# Altium schematic sheet PowerSupply.SchDoc(PowerSupply)
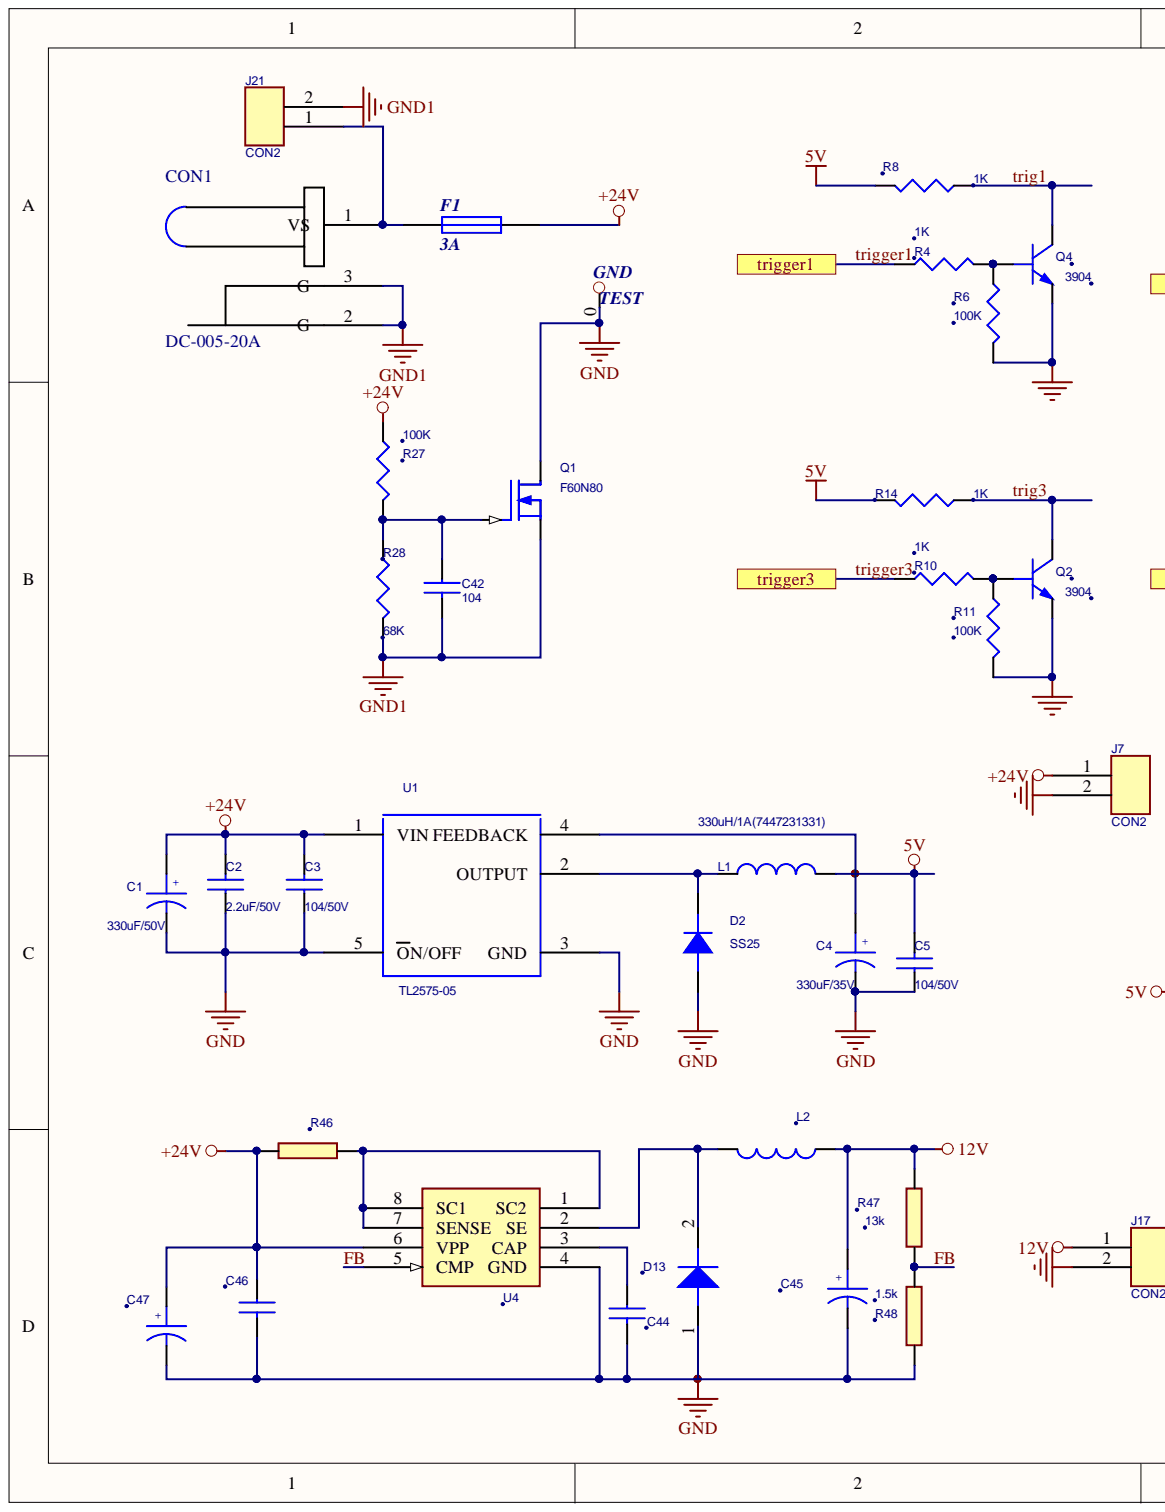
[diagram p0: PowerSupply.SchDoc, left]
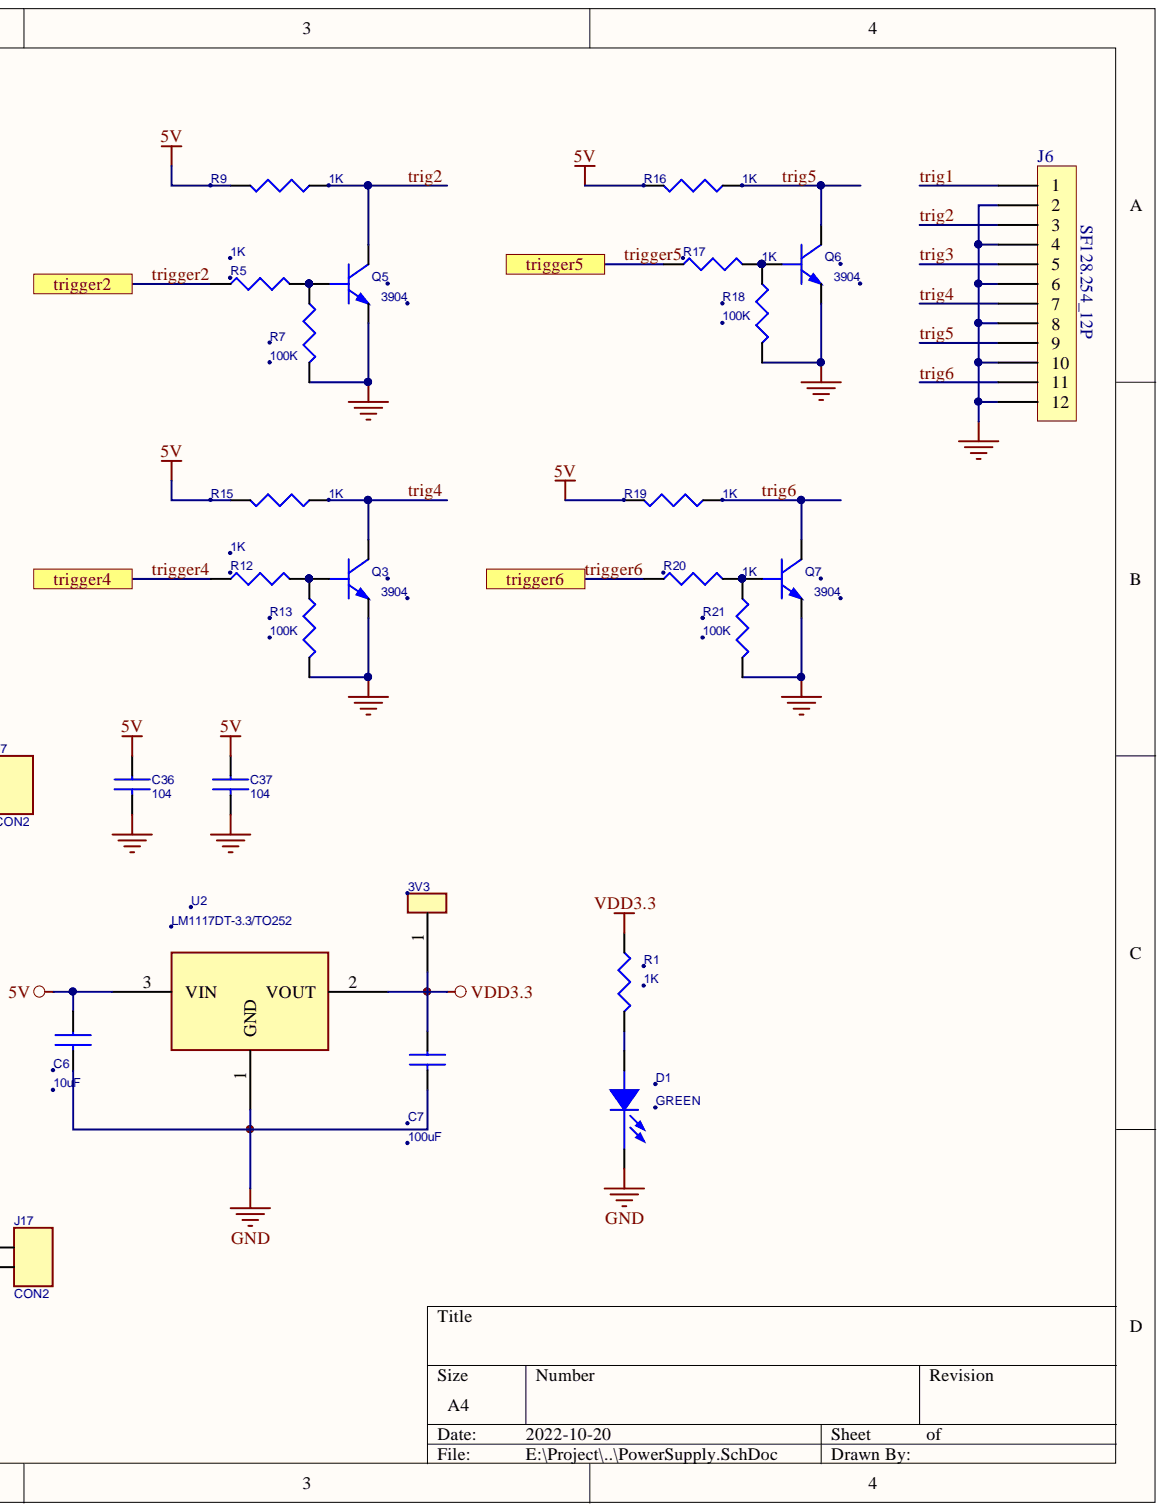
[diagram p1: PowerSupply.SchDoc, right]

## Parts
3V3  TEST POINT  [TEST2]  (value not drawn)
C1  330uF/50V  [EC_1000UF]
C2  2.2uF/50V  [C1206]
C3  104/50V  [0603C]
C4  330uF/35V  [EC_100UF]
C5  104/50V  [0603C]
C6  10uF  [C1206]
C7  100uF  [C1206]
C36  104  [0603C]
C37  104  [0603C]
C42  104  [0603C]
C44  200p  [0603C]  (value not drawn)
C45  470uF/35V  [EC_100UF]  (value not drawn)
C46  104  [0603C]  (value not drawn)
C47  470uF/35V  [EC_100UF]  (value not drawn)
CON1  DC-005-20A  [DC-IN-TH_DC005]
D1  GREEN  [D0603]
D2  SS25  [D1210]
D13  SS34  [d1210]  (value not drawn)
F1  3A  [F1206]
GND  TEST  [TESTPOINT]
J6  SF128.254_12P  [SF128.254_12P]
J7  CON2  [KF128.254_2P]
J17  CON2  [KF128.254_2P]
L1  330uH/1A(7447231331)  [L11X19]
L2  YSPI0850-470M  [YSPI0850]  (value not drawn)
Q1  F60N80  [TO252-3]
Q2  3904  [SOT23]
Q3  3904  [SOT23]
Q4  3904  [SOT23]
Q5  3904  [SOT23]
Q6  3904  [SOT23]
Q7  3904  [SOT23]
R1  1K  [0603R]
R4  1K  [0603R]
R5  1K  [0603R]
R6  100K  [0603R]
R7  100K  [0603R]
R8  1K  [0603R]
R9  1K  [0603R]
R10  1K  [0603R]
R11  100K  [0603R]
R12  1K  [0603R]
R13  100K  [0603R]
R14  1K  [0603R]
R15  1K  [0603R]
R16  1K  [0603R]
R17  1K  [0603R]
R18  100K  [0603R]
R19  1K  [0603R]
R20  1K  [0603R]
R21  100K  [0603R]
R27  100K  [0603R]
R28  68K  [0603R]
R46  0.3R  [R1206]  (value not drawn)
R47  13k  [0603R]
R48  1.5k  [0603R]
U1  TL2575-05  [TO-263]
U2  LM1117DT-3.3/TO252  [TO252-3]
U4  MC34063A/SOIC08  [SOIC-8]  (value not drawn)

## Ports
trigger1, trigger2, trigger3, trigger4, trigger5, trigger6

## Nets
5V: C4.1 C5.2 C6.1 C36.1 C37.1 L1.2 R8.2 R9.2 R14.2 R15.2 R16.2 R19.2 U1.4(FEEDBACK) U2.3
12V: C45.1 J17.1 L2.2 R47.1
+24V: C1.1 C2.2 C3.2 C46.1 C47.1 F1.1 J7.1 R27.1 R46.1 U1.1(VIN) U4.6(VPP)
GND: C1.2 C2.1 C3.1 C4.2 C5.1 C6.2 C7.2 C36.2 C37.2 C44.2 C45.2 C46.2 C47.2 D1.2 D2.2 D13.1 GND.0 J6.2 J6.4 J6.6 J6.8 J6.10 J6.12 J7.2 J17.2 Q1.2 Q2.2 Q3.2 Q4.2 Q5.2 Q6.2 Q7.2 R6.1 R7.1 R11.1 R13.1 R18.1 R21.1 R48.2 U1.3(GND) U1.5(ON/OFF) U2.1 U4.4(GND)
GND1: C42.2 CON1.2 CON1.3 Q1.3 R28.2
VDD3.3: 3V3.1 C7.1 R1.1 U2.2
FB: R47.2 R48.1 U4.5(CMP)
trig1: J6.1 Q4.3 R8.1
trig2: J6.3 Q5.3 R9.1
trig3: J6.5 Q2.3 R14.1
trig4: J6.7 Q3.3 R15.1
trig5: J6.9 Q6.3 R16.1
trig6: J6.11 Q7.3 R19.1
trigger1: R4.1 ; port trigger1
trigger2: R5.1 ; port trigger2
trigger3: R10.1 ; port trigger3
trigger4: R12.1 ; port trigger4
trigger5: R17.1 ; port trigger5
trigger6: R20.1 ; port trigger6
NetC42_1: C42.1 Q1.1 R27.2 R28.1
NetC44_1: C44.1 U4.3(CAP)
NetCON1_1: CON1.1 F1.2
NetD1_1: D1.1 R1.2
NetD2_1: D2.1 L1.1 U1.2(OUTPUT)
NetD13_2: D13.2 L2.1 U4.2(SE)
NetQ2_1: Q2.1 R10.2 R11.2
NetQ3_1: Q3.1 R12.2 R13.2
NetQ4_1: Q4.1 R4.2 R6.2
NetQ5_1: Q5.1 R5.2 R7.2
NetQ6_1: Q6.1 R17.2 R18.2
NetQ7_1: Q7.1 R20.2 R21.2
NetR46_2: R46.2 U4.1(SC2) U4.7(SENSE) U4.8(SC1)

## Library data of the parts
C1 C4 = CAP POL: Component Kind: Standard
C2 C3 C5 C36 C37 C42 = CAP NP: Component Kind: Standard
C6 C7 = CAP NP: Tolerate: 10%; Component Kind: Standard
C44 = CAPACITOR NON-POL: Component Kind: Standard
C45 C47 = CAPACITOR POL: Component Kind: Standard
C46 = CAP NP_0: Component Kind: Standard
D1 = MR3050: Component Kind: Standard
D2 = DIODE PIN: Component Kind: Standard
D13 = 1N5819_1: Component Kind: Standard
F1 = FUSE: Component Kind: Standard; Description: FUSE; Library Name: Piezo_Drive_HW.SchLib
J6 = CON12: Component Kind: Standard; Library Name: TeenSy_1.SCHLIB
L1 = CHOKE: Component Kind: Standard
L2 = INDUCTOR: Component Kind: Standard
Q1 = MOSFET N_4: Component Kind: Standard
Q2-Q7 = NPN BEC: Component Kind: Standard
R1 R4-R21 R27 R28 = R: Component Kind: Standard
R46-R48 = RES_12: Component Kind: Standard
U1 = TL2575_1: Component Kind: Standard
U2 = LM1117DT-5.0/TO252: Component Kind: Standard
U4 = KA34063A/SOIC8: Component Kind: Standard
3V3 = TEST POINT: Component Kind: Standard
CON1 = DC-005-20A: Component Kind: Standard; Description: DC-005-20A; Library Name: Piezo_Drive_HW.SchLib
GND = TEST: Component Kind: Standard; Library Name: Piezo_Drive_HW.SchLib
J7 J17 = CON2: Component Kind: Standard; Library Name: TeenSy_1.SCHLIB
